# Altium schematic sheet FLYBACK_SWITCHING_POWER_SUPPLY.SchDoc(FLYBACK_SWITCHING_POWER_SUPPLY)
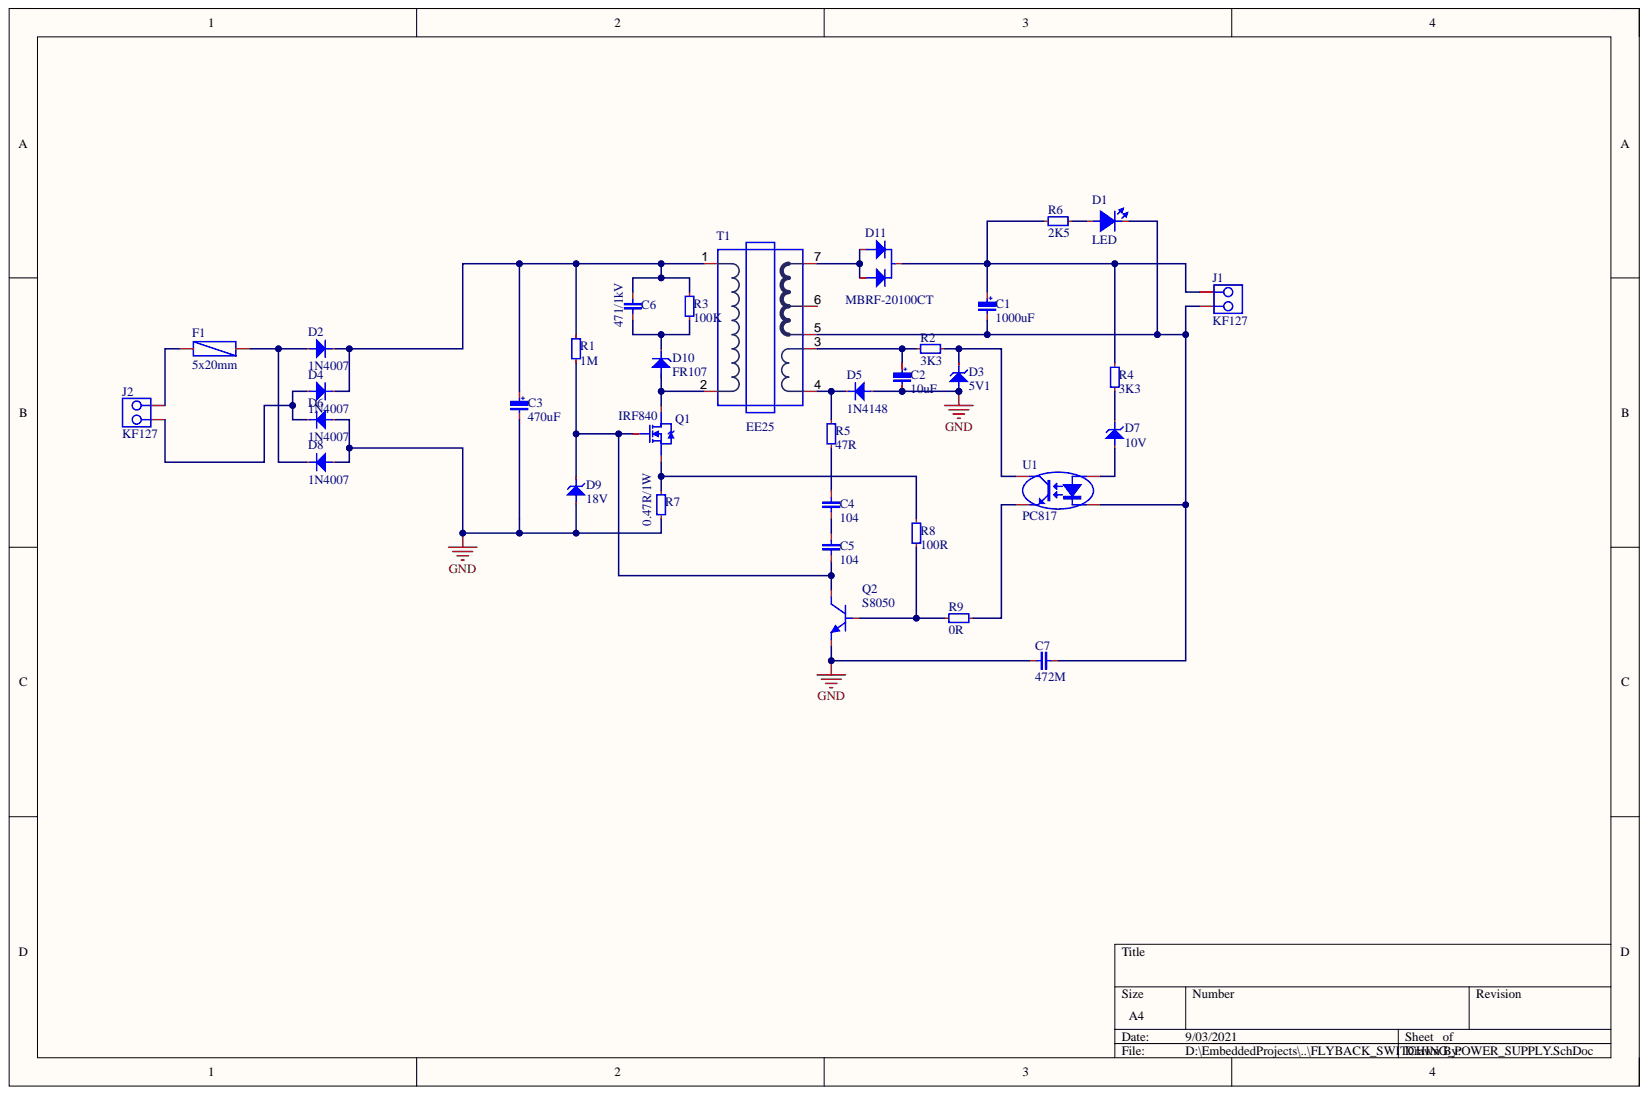
[diagram p0: FLYBACK_SWITCHING_POWER_SUPPLY.SchDoc]

## Parts
C1  1000uF  [CAP_RAD_D12.5x15]
C2  10uF  [CAP_RAD_D05x11]
C3  470uF  [CAP_RAD_D12.5x15]
C4  104  [CAP_Ceramic_2.5MM]
C5  104  [CAP_Ceramic_2.5MM]
C6  471/1kV  [CAP_Ceramic_5MM]
C7  472M  [CAP_Ceramic_472M_7.21MM]
D1  LED  [LED_5MM]
D2  1N4007  [DO-204AL-BK]
D3  5V1  [DO-204AL-RD]
D4  1N4007  [DO-204AL-BK]
D5  1N4148  [DO-204AH-RD]
D6  1N4007  [DO-204AL-BK]
D7  10V  [DO-204AL-RD]
D8  1N4007  [DO-204AL-BK]
D9  18V  [DO-204AL-RD]
D10  FR107  [DO-204AL-BK]
D11  MBRF-20100CT  [TO-220F]
F1  5x20mm  [FUSE_Bel_MJS]
J1  KF127  [DG127-5.0-02P-14-00AH]
J2  KF127  [DG127-5.0-02P-14-00AH]
Q1  IRF840  [TO-220-HEAT-SINK-1]
Q2  S8050  [TO-92]
R1  1M  [RES-0.25W-H]
R2  3K3  [RES-0.25W-H]
R3  100K  [RES-0.5W-H]
R4  3K3  [RES-0.25W-H]
R5  47R  [RES-0.25W-H]
R6  2K5  [RES-0.25W-H]
R7  0.47R/1W  [RES-2W-H]
R8  100R  [RES-0.25W-H]
R9  0R  [RES-0.25W-H]
T1  EE25  [TRANSFORMER-FLUOLAMP-8W]
U1  PC817  [PC817-DIP]

## Nets
GND: C2.2 C3.2 C7.1 D3.1 D5.1 D6.1 D8.1 D9.1 Q2.1 R7.1
NetC1_1: C1.1 D11.2 J1.1 R4.2 R6.1
NetC1_2: C1.2 C7.2 D1.2 J1.2 T1.5 U1.2
NetC2_1: C2.1 R2.1 T1.3
NetC3_1: C3.1 C6.2 D2.2 D4.2 R1.2 R3.2 T1.1
NetC4_1: C4.1 C5.2
NetC4_2: C4.2 R5.1
NetC5_1: C5.1 D9.2 Q1.1 Q2.3 R1.1
NetC6_1: C6.1 D10.2 R3.1
NetD1_1: D1.1 R6.2
NetD2_1: D2.1 D8.2 F1.2
NetD3_2: D3.2 R2.2 U1.4
NetD4_1: D4.1 D6.2 J2.1
NetD5_2: D5.2 R5.2 T1.4
NetD7_1: D7.1 U1.1
NetD7_2: D7.2 R4.1
NetD10_1: D10.1 Q1.2 T1.2
NetD11_1: D11.1 D11.3 T1.7
NetF1_1: F1.1 J2.2
NetQ1_3: Q1.3 R7.2 R8.2
NetQ2_2: Q2.2 R8.1 R9.2
NetR9_1: R9.1 U1.3

Not connected: T1 6

## Library data of the parts
C1-C3 = Capacitor(Radian): Component Kind: Standard; Library Name: MyAltiumLibrary.IntLib
C4-C7 = Capacitors(Disc): Component Kind: Standard; Library Name: MyAltiumLibrary.IntLib
D1 = LED 5MM: Component Kind: Standard; Library Name: MyAltiumLibrary.IntLib
D2 D4 D6 D8 = Diode-1N4007: Component Kind: Standard; Library Name: MyAltiumLibrary.IntLib
D3 D7 D9 = Diode-Zener: Component Kind: Standard; Library Name: MyAltiumLibrary.IntLib
D5 = Diode-1N4148: Component Kind: Standard; Library Name: MyAltiumLibrary.IntLib
D10 = Diode-FR107: 1A 1000V; Component Kind: Standard; Library Name: MyAltiumLibrary.IntLib
D11 = Diode-MBRF-20100CT: Component Kind: Standard; Library Name: MyAltiumLibrary.IntLib
F1 = Fuse-5x20mm: Component Kind: Standard; Library Name: MyAltiumLibrary.IntLib
J1 J2 = DG127-5.0-02P-14-00AH: Component Kind: Standard; Library Name: MyAltiumLibrary.IntLib
Q1 = Mosfet-IRF840: Component Kind: Standard; Library Name: MyAltiumLibrary.IntLib
Q2 = Transistor-2N3904 (TO-92): Component Kind: Standard; Library Name: MyAltiumLibrary.IntLib
R1-R9 = Resistors THD: Component Kind: Standard; Library Name: MyAltiumLibrary.IntLib
T1 = TRANS-FLUOLAMP-8W-VERTICAL: Component Kind: Standard; Library Name: MyAltiumLibrary.IntLib
U1 = Opto-PC817: Component Kind: Standard; Library Name: MyAltiumLibrary.IntLib
